# Altium schematic sheet DEVICE2.SchDoc
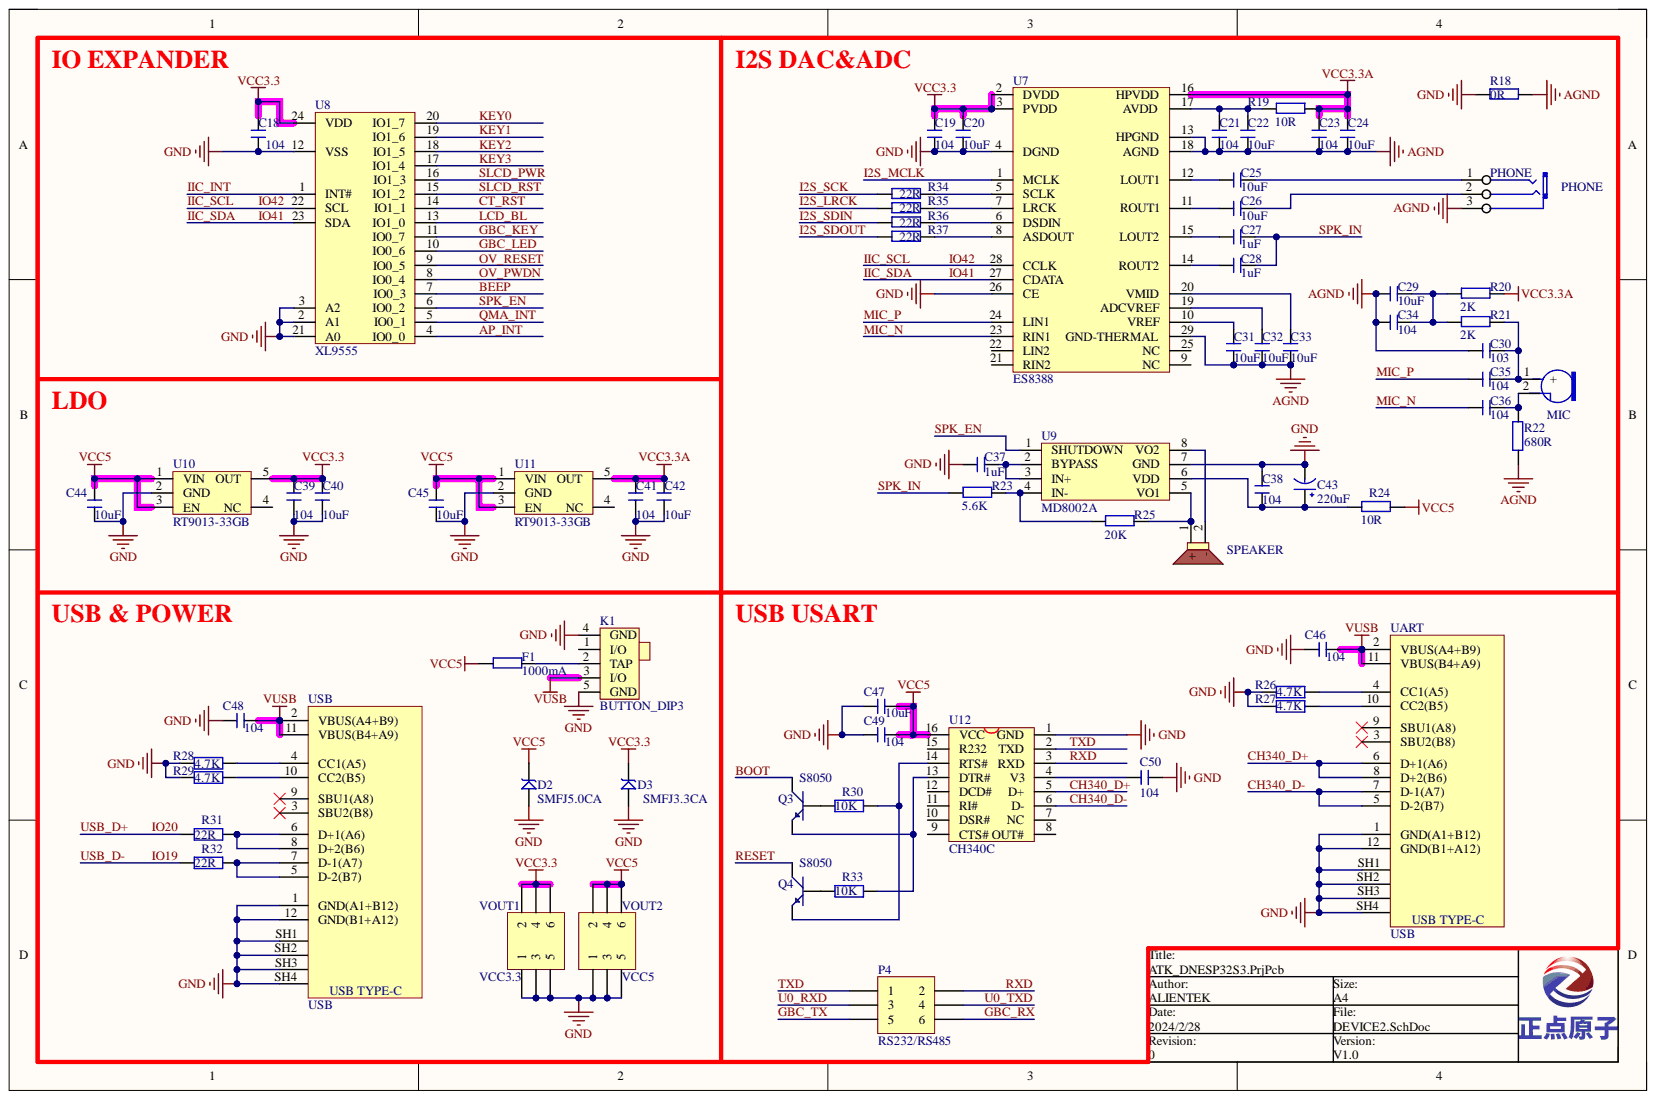
[diagram p0: DEVICE2.SchDoc]

## Parts
C18  104  [C 0402]
C19  104  [C 0402]
C20  10uF  [C 0603]
C21  104  [C 0402]
C22  10uF  [C 0603]
C23  104  [C 0402]
C24  10uF  [C 0603]
C25  10uF  [C 0603]
C26  10uF  [C 0603]
C27  1uF  [C 0402]
C28  1uF  [C 0402]
C29  10uF  [C 0603]
C30  103  [C 0402]
C31  10uF  [C 0603]
C32  10uF  [C 0603]
C33  10uF  [C 0603]
C34  104  [C 0402]
C35  104  [C 0402]
C36  104  [C 0402]
C37  1uF  [C 0402]
C38  104  [C 0402]
C39  104  [C 0402]
C40  10uF  [C 0805]
C41  104  [C 0402]
C42  10uF  [C 0805]
C43  220uF  [CC6.56.5]
C44  10uF  [C 0603]
C45  10uF  [C 0603]
C46  104  [C 0402]
C47  10uF  [C 0603]
C48  104  [C 0402]
C49  104  [C 0402]
C50  104  [C 0402]
D2  SMFJ5.0CA  [SOD-123]
D3  SMFJ3.3CA  [SOD-123]
F1  1000mA  [0805]
K1  BUTTON_DIP3  [BTN8.8*4.6]
MIC  MIC  [MIC-6022]
P4  RS232/RS485  [HDR2X3]
PHONE  PHONE  [PHONE_ST]
Q3  S8050  [SOT-23R]
Q4  S8050  [SOT-23R]
R18  0R  [R 0402]
R19  10R  [R 0402]
R20  2K  [R 0402]
R21  2K  [R 0402]
R22  680R  [R 0402]
R23  5.6K  [R 0402]
R24  10R  [R 0402]
R25  20K  [R 0402]
R26  4.7K  [R 0402]
R27  4.7K  [R 0402]
R28  4.7K  [R 0402]
R29  4.7K  [R 0402]
R30  10K  [R 0402]
R31  22R  [R 0402]
R32  22R  [R 0402]
R33  10K  [R 0402]
R34  22R  [R 0402]
R35  22R  [R 0402]
R36  22R  [R 0402]
R37  22R  [R 0402]
SPEAKER  2307  [SPEAKER]  (value not drawn)
U7  ES8388  [QFN28 4X4_L]
U8  XL9555  [TSSOP24_M]
U9  MD8002A  [SOP-8]
U10  RT9013-33GB  [SOT23-5N]
U11  RT9013-33GB  [SOT23-5N]
U12  CH340C  [SOP16M]
UART  USB  [USB Type-C]
USB  USB  [USB Type-C]
VOUT1  VCC3.3  [HDR2X3]
VOUT2  VCC5  [HDR2X3]

## Nets
AGND: C21.1 C22.1 C23.1 C24.1 C29.2 C30.2 C31.1 C32.1 C33.1 C34.2 PHONE.3 R18.1 R22.2 U7.13(HPGND) U7.18(AGND) U7.29(GND-THERMAL)
GND: C18.1 C19.1 C20.1 C37.2 C38.1 C39.1 C40.1 C41.1 C42.1 C43.2 C44.1 C45.1 C46.2 C47.2 C48.2 C49.2 C50.1 D2.1 D3.1 K1.4(GND) K1.5(GND) R18.2 R26.1 R27.1 R28.1 R29.1 U7.4(DGND) U7.26(CE) U8.2(A1) U8.3(A2) U8.12(VSS) U8.21(A0) U9.7(GND) U10.2(GND) U11.2(GND) U12.1(GND) UART.1(GND(A1+B12)) UART.12(GND(B1+A12)) UART.SH1(Shield1) UART.SH2(Shield2) UART.SH3(Shield3) UART.SH4(Shield4) USB.1(GND(A1+B12)) USB.12(GND(B1+A12)) USB.SH1(Shield1) USB.SH2(Shield2) USB.SH3(Shield3) USB.SH4(Shield4) VOUT1.1 VOUT1.3 VOUT1.5 VOUT2.1 VOUT2.3 VOUT2.5
VCC3.3: C18.2 C19.2 C20.2 C39.2 C40.2 D3.2 U7.2(DVDD) U7.3(PVDD) U8.24(VDD) U10.5(OUT) VOUT1.2 VOUT1.4 VOUT1.6
VCC3.3A: C23.2 C24.2 C41.2 C42.2 R19.1 R20.1 U7.16(HPVDD) U11.5(OUT)
VCC5: C44.2 C45.2 C47.1 C49.1 D2.2 F1.2 R24.1 U10.1(VIN) U10.3(EN) U11.1(VIN) U11.3(EN) U12.16(VCC) VOUT2.2 VOUT2.4 VOUT2.6
VUSB: C46.1 C48.1 K1.3(I/O) UART.2(VBUS(A4+B9)) UART.11(VBUS(B4+A9)) USB.2(VBUS(A4+B9)) USB.11(VBUS(B4+A9))
AP_INT: U8.4(IO0_0)
BEEP: U8.7(IO0_3)
BOOT: Q3.3
CH340_D+: U12.5(D+) UART.6(D+1(A6)) UART.8(D+2(B6))
CH340_D-: U12.6(D-) UART.5(D-2(B7)) UART.7(D-1(A7))
CT_RST: U8.14(IO1_1)
GBC_KEY: U8.11(IO0_7)
GBC_LED: U8.10(IO0_6)
GBC_RX: P4.6
GBC_TX: P4.5
I2S_LRCK: R35.2
I2S_MCLK: U7.1(MCLK)
I2S_SCK: R34.2
I2S_SDIN: R36.2
I2S_SDOUT: R37.2
IIC_INT: U8.1(INT#)
IIC_SCL: U7.28(CCLK) U8.22(SCL)
IIC_SDA: U7.27(CDATA) U8.23(SDA)
IO19: R32.1
IO20: R31.1
KEY0: U8.20(IO1_7)
KEY1: U8.19(IO1_6)
KEY2: U8.18(IO1_5)
KEY3: U8.17(IO1_4)
LCD_BL: U8.13(IO1_0)
MIC_N: C36.2 U7.23(RIN1)
MIC_P: C35.2 U7.24(LIN1)
OV_PWDN: U8.8(IO0_4)
OV_RESET: U8.9(IO0_5)
QMA_INT: U8.5(IO0_1)
RESET: Q4.3
RXD: P4.2 U12.3(RXD)
SLCD_PWR: U8.16(IO1_3)
SLCD_RST: U8.15(IO1_2)
SPK_EN: U8.6(IO0_2) U9.1(SHUTDOWN)
SPK_IN: C27.1 C28.1 R23.2
TXD: P4.1 U12.2(TXD)
U0_RXD: P4.3
U0_TXD: P4.4
NetC21_2: C21.2 C22.2 R19.2 U7.17(AVDD)
NetC25_1: C25.1 PHONE.1
NetC25_2: C25.2 U7.12(LOUT1)
NetC26_1: C26.1 PHONE.2
NetC26_2: C26.2 U7.11(ROUT1)
NetC27_2: C27.2 U7.15(LOUT2)
NetC28_2: C28.2 U7.14(ROUT2)
NetC29_1: C29.1 C34.1 R20.2 R21.2
NetC30_1: C30.1 C35.1 MIC.1 R21.1
NetC31_2: C31.2 U7.10(VREF)
NetC32_2: C32.2 U7.19(ADCVREF)
NetC33_2: C33.2 U7.20(VMID)
NetC36_1: C36.1 MIC.2 R22.1
NetC37_1: C37.1 U9.2(BYPASS) U9.3(IN+)
NetC38_2: C38.2 C43.1 R24.2 U9.6(VDD)
NetC50_2: C50.2 U12.4(V3)
NetF1_1: F1.1 K1.2(TAP)
NetQ3_1: Q3.1 R33.2 U12.13(DTR#)
NetQ3_2: Q3.2 R30.1
NetQ4_1: Q4.1 R30.2 U12.14(RTS#)
NetQ4_2: Q4.2 R33.1
NetR23_1: R23.1 R25.2 U9.4(IN-)
NetR25_1: R25.1 SPEAKER.1 U9.5(VO1)
NetR26_2: R26.2 UART.4(CC1(A5))
NetR27_2: R27.2 UART.10(CC2(B5))
NetR28_2: R28.2 USB.4(CC1(A5))
NetR29_2: R29.2 USB.10(CC2(B5))
NetR31_2: R31.2 USB.6(D+1(A6)) USB.8(D+2(B6))
NetR32_2: R32.2 USB.5(D-2(B7)) USB.7(D-1(A7))
NetR34_1: R34.1 U7.5(SCLK)
NetR35_1: R35.1 U7.7(LRCK)
NetR36_1: R36.1 U7.6(DSDIN)
NetR37_1: R37.1 U7.8(ASDOUT)
NetSPEAKER_2: SPEAKER.2 U9.8(VO2)

Not connected: K1 1(I/O); U7 9(NC) 21(RIN2) 22(LIN2) 25(NC); U10 4(NC); U11 4(NC); U12 7(NC) 8(OUT#) 9(CTS#) 10(DSR#) 11(RI#) 12(DCD#) 15(R232); UART 3(SBU2(B8)) 9(SBU1(A8)); USB 3(SBU2(B8)) 9(SBU1(A8))

## Library data of the parts
C18-C42 C44-C50 = C: 无极性电容; BOM名称: 无极性贴片电容; 版权归属: 正点原子; Component Kind: Standard; Library Name: 电容.SchLib; 学习资源: www.openedv.com
C43 = CAP: Component Kind: Standard; Library Name: MYCOMLIB.IntLib
D2 D3 = SMBJ: TVS; Component Kind: Standard; Library Name: ALIENTEK_COMPONENT.IntLib
F1 R18-R25 R34-R37 = R: Component Kind: Standard; Library Name: MYCOMLIB.IntLib
MIC = MIC-6022: Component Kind: Standard; Description: MIC; Library Name: Alientek_Integrated_Library.IntLib
P4 VOUT1 VOUT2 = Header 3X2: Header, 3-Pin, Dual row; Component Kind: Standard; LatestRevisionDate: 17-Jul-2002; LatestRevisionNote: Re-released for DXP Platform.; Library Name: Miscellaneous Connectors.IntLib; Publisher: Altium Limited
PHONE = PHONE_M: Component Kind: Standard; Library Name: ALIENTEK_COMPONENT.IntLib
Q3 Q4 = NPN: 8050/BCW846/BCW847; Component Kind: Standard; Library Name: ALIENTEK_COMPONENT.IntLib
R26-R33 = R: 电阻; BOM名称: 贴片电阻; 版权归属: 正点原子; Component Kind: Standard; Library Name: 正点原子封装库V1.0.IntLib; Parameter: 电阻; 学习资源: www.openedv.com
SPEAKER = SPEAKER: 2307喇叭，8Ω 1W，23mm*7mm，2P; Component Kind: Standard; Library Name: 刘军临时封装库_SCH库.SchLib
U7 = ES8388: 24bit ADC&DAC 96Khz; Parameter: 芯片; 版权归属: 正点原子; Component Kind: Standard; Library Name: 芯片.SchLib; 学习资源: www.openedv.com
U8 = XL9555: IO扩展芯片; Component Kind: Standard; Library Name: 刘军临时封装库_SCH库.SchLib
U9 = MD8002A: 5V, 3W, 功放IC; Component Kind: Standard; Library Name: ALIENTEK_COMPONENT.IntLib
U10 U11 = RT9013: LDO 5.5V 500mA; BOM名称: 稳压芯片; Parameter: 芯片; 版权归属: 正点原子; Component Kind: Standard; Library Name: 芯片_LDO_DCDC电源.SchLib; 学习资源: www.openedv.com
U12 = CH340C: USB2UART; Component Kind: Standard; Library Name: ALIENTEK_COMPONENT.IntLib
UART USB = USB Type-C: 12P TypeC 母座; Component Kind: Standard; Library Name: 接口类.SchLib
K1 = BUTTON_DIP3: Component Kind: Standard; Library Name: ALIENTEK_COMPONENT.IntLib
